# Altium schematic sheet Connect.SchDoc
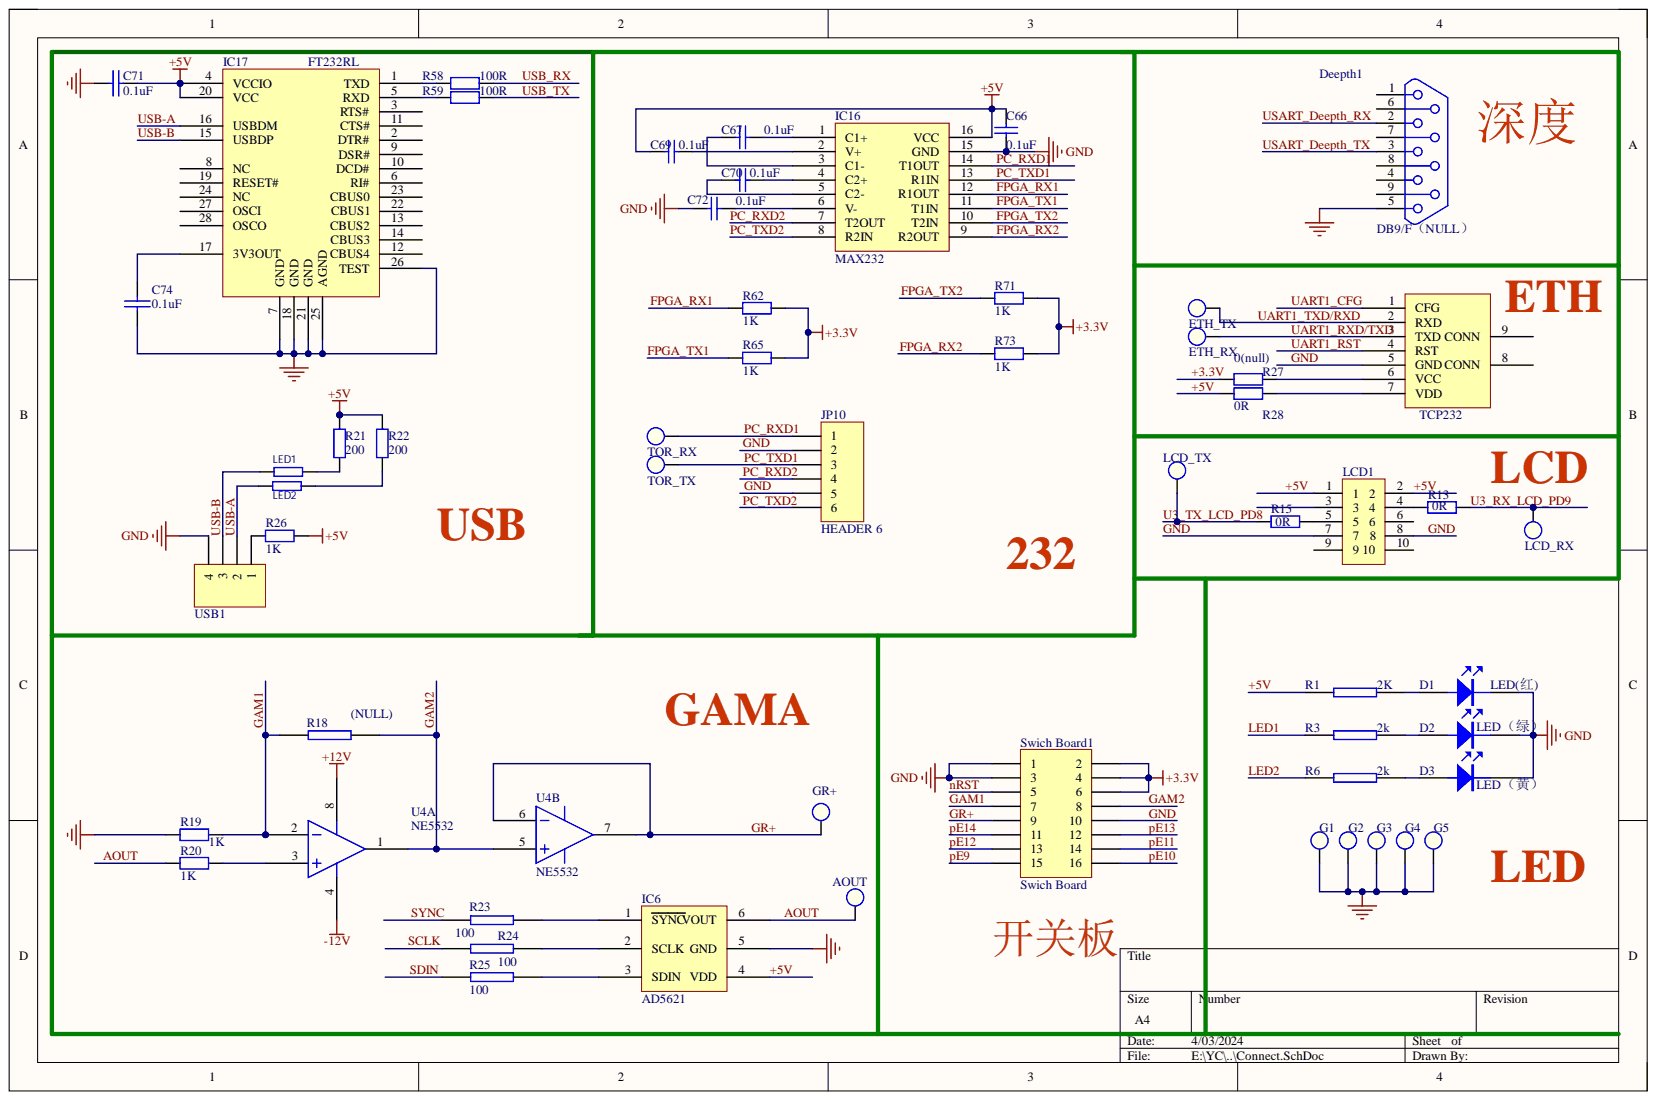
[diagram p0: Connect.SchDoc]

## Parts
AOUT  TERM  [TERM]  (value not drawn)
C66  0.1uF  [0805C]
C67  0.1uF  [0805C]
C69  0.1uF  [0805C]
C70  0.1uF  [0805C]
C71  0.1uF  [0805C]
C72  0.1uF  [0805C]
C74  0.1uF  [0805C]
D1  LED(红)  [1206D]
D2  LED（绿）  [1206D]
D3  LED（黄）  [1206D]
Deepth1  DB9/F（NULL）  [DB9/F]
ETH1(薄膜座)  Module_TCP232  [Module_TCP_232]  (value not drawn)
ETH_RX  TERM  [TERM]  (value not drawn)
ETH_TX  TERM  [TERM]  (value not drawn)
G1  TERM  [TERM]  (value not drawn)
G2  TERM  [TERM]  (value not drawn)
G3  TERM  [TERM]  (value not drawn)
G4  TERM  [TERM]  (value not drawn)
G5  TERM  [TERM]  (value not drawn)
GR+  TERM  [TERM]  (value not drawn)
IC6  AD5621  [SOP6]
IC16  MAX232  [SOP16]
IC17  FT232RL  [SSOP28]
JP10  HEADER 6  [J-DG128-6]
LCD1  HEADER 5X2  [IDC10_2.54]  (value not drawn)
LCD_RX  TERM  [TERM]  (value not drawn)
LCD_TX  TERM  [TERM]  (value not drawn)
LED1  (no value)  [0805D]
LED2  (no value)  [0805D]
R1  2K  [0805]
R3  2k  [0805]
R6  2k  [0805]
R13  0R  [0805]
R15  0R  [0805]
R18  (NULL)  [0805]
R19  1K  [0805]
R20  1K  [0805]
R21  200R  value 200  [0805]
R22  200R  value 200  [0805]
R23  100  [0805]
R24  100  [0805]
R25  100  [0805]
R26  1K  [0805]
R27  0(null)  [0805]
R28  0R  [0805]
R58  100R  [0805]
R59  100R  [0805]
R62  1K  [0805]
R65  1K  [0805]
R71  1K  [0805]
R73  1K  [0805]
Swich_Board1  Swich Board  [IDC16_2.54]
TOR_RX  TERM  [TERM]  (value not drawn)
TOR_TX  TERM  [TERM]  (value not drawn)
U4  NE5532  [SOIC8]  (parts A,B)
USB1  Header 4  [USB-A]  (value not drawn)

## Nets
+12V: U4.8
-12V: U4.4
+3.3V: R27.1 R62.1 R65.1 R71.1 R73.1 Swich_Board1.2 Swich_Board1.4 Swich_Board1.6
+5V: C66.2 C69.1 C71.1 IC6.4(VDD) IC16.16(VCC) IC17.4(VCCIO) IC17.20(VCC) LCD1.1 LCD1.2 R1.1 R21.2 R22.2 R26.2 R28.1
AOUT: AOUT.1 IC6.6(VOUT) R20.2
FPGA_RX1: IC16.12(R1OUT) R62.2
FPGA_RX2: IC16.9(R2OUT) R73.2
FPGA_TX1: IC16.11(T1IN) R65.2
FPGA_TX2: IC16.10(T2IN) R71.2
GAM1: R18.1 R19.1 Swich_Board1.7 U4.2
GAM2: R18.2 Swich_Board1.8 U4.1 U4.5
GND: C66.1 C71.2 C72.1 C74.2 D1.2 D2.2 D3.2 Deepth1.5 ETH1(薄膜座).5 G1.1 G2.1 G3.1 G4.1 G5.1 IC6.5(GND) IC16.15(GND) IC17.7(GND) IC17.18(GND) IC17.21(GND) IC17.25(AGND) IC17.26(TEST) JP10.2 JP10.5 LCD1.7 LCD1.8 R19.2 Swich_Board1.1 Swich_Board1.3 Swich_Board1.10 USB1.4
GR+: GR+.1 Swich_Board1.9 U4.6 U4.7
LED1: R3.1
LED2: R6.2
nRST: Swich_Board1.5
PC_RXD1: IC16.14(T1OUT) JP10.1 TOR_RX.1
PC_RXD2: IC16.7(T2OUT) JP10.4
PC_TXD1: IC16.13(R1IN) JP10.3 TOR_TX.1
PC_TXD2: IC16.8(R2IN) JP10.6
pE9: Swich_Board1.15
pE10: Swich_Board1.16
pE11: Swich_Board1.14
pE12: Swich_Board1.13
pE13: Swich_Board1.12
pE14: Swich_Board1.11
SCLK: R24.1
SDIN: R25.1
SYNC: R23.1
U3_RX_LCD_PD9: LCD_RX.1 R13.1
U3_TX_LCD_PD8: LCD_TX.1 R15.2
UART1_CFG: ETH1(薄膜座).1
UART1_RST: ETH1(薄膜座).4
UART1_RXD/TXD: ETH1(薄膜座).3 ETH_RX.1
UART1_TXD/RXD: ETH1(薄膜座).2 ETH_TX.1
USART_Deepth_RX: Deepth1.2
USART_Deepth_TX: Deepth1.3
USB-A: IC17.16(USBDM) LED2.1 USB1.2
USB-B: IC17.15(USBDP) LED1.1 USB1.3
USB_RX: R58.2
USB_TX: R59.2
NetC67_1: C67.1 IC16.3(C1-)
NetC67_2: C67.2 IC16.1(C1+)
NetC69_2: C69.2 IC16.2(V+)
NetC70_1: C70.1 IC16.5(C2-)
NetC70_2: C70.2 IC16.4(C2+)
NetC72_2: C72.2 IC16.6(V-)
NetC74_1: C74.1 IC17.17(3V3OUT)
NetD1_1: D1.1 R1.2
NetD2_1: D2.1 R3.2
NetD3_1: D3.1 R6.1
NetETH1(薄膜座)_6: ETH1(薄膜座).6 R27.2
NetETH1(薄膜座)_7: ETH1(薄膜座).7 R28.2
NetIC6_1: IC6.1(SYNC) R23.2
NetIC6_2: IC6.2(SCLK) R24.2
NetIC6_3: IC6.3(SDIN) R25.2
NetIC17_1: IC17.1(TXD) R58.1
NetIC17_5: IC17.5(RXD) R59.1
NetLCD1_4: LCD1.4 R13.2
NetLCD1_5: LCD1.5 R15.1
NetLED1_2: LED1.2 R21.1
NetLED2_2: LED2.2 R22.1
NetR20_1: R20.1 U4.3
NetR26_1: R26.1 USB1.1

Not connected: Deepth1 1 4 6 7 8 9; ETH1(薄膜座) 8 9; IC17 2(DTR#) 3(RTS#) 6(RI#) 8(NC) 9(DSR#) 10(DCD#) 11(CTS#) 12(CBUS4) 13(CBUS2) 14(CBUS3) 19(RESET#) 22(CBUS1) 23(CBUS0) 24(NC) 27(OSCI) 28(OSCO); LCD1 3 6 9 10

## Library data of the parts
C66 C67 C69 C70 C72 = Cap: Capacitor; Component Kind: Standard; LatestRevisionDate: 17-Jul-2002; LatestRevisionNote: Re-released for DXP Platform.; Library Name: Miscellaneous Devices.IntLib; PackageReference: RAD-0.3; Published: 8-Jun-2000; Signal Integrity: Cap; Simulation: CAP; Value: 0.1uF; Publisher: Altium Limited
C71 C74 = CAP: Capacitor; Component Kind: Standard; Value: 0.1uF
D1-D3 = LED: Component Kind: Standard; Library Name: 杂元件库.SCHLIB
Deepth1 = DB9: Component Kind: Standard; Library Name: 杂元件库.SCHLIB
JP10 = HEADER 6: 排针/排母; Component Kind: Standard; Library Name: TR_SCHLIB.SchLib
LCD1 = HEADER: Component Kind: Standard; Library Name: 杂元件库.SCHLIB
LED1 LED2 = RES: Component Kind: Standard; Library Name: 2.SCHLIB
R1 R3 R6 R18 R23-R25 = RES2: Component Kind: Standard
  R18: : (NULL); Library Name: Backup of 99SE.Lib
  R1 R3 R6: Library Name: downhole.SchLib
R13 R15 = Res2: Resistor; Value: 0; Component Kind: Standard; LatestRevisionDate: 17-Jul-2002; LatestRevisionNote: Re-released for DXP Platform.; Library Name: Miscellaneous Devices.IntLib; PackageDescription: Axial Device, Thru-Hole; 2 Leads; 0.4 in Pin Spacing; PackageReference: AXIAL-0.4; Published: 8-Jun-2000; Signal Integrity: Res; Simulation: RESISTOR; Publisher: Altium Limited
R19-R22 R26 R58 R59 R62 R65 R71 R73 = Res2: Resistor; Component Kind: Standard; LatestRevisionDate: 17-Jul-2002; LatestRevisionNote: Re-released for DXP Platform.; PackageDescription: Axial Device, Thru-Hole; 2 Leads; 0.4 in Pin Spacing; PackageReference: AXIAL-0.4; Published: 8-Jun-2000; Signal Integrity: Res; Simulation: RESISTOR; Publisher: Altium Limited
  R19 R20 R26 R62 R65 R71 R73: Library Name: CONN.SchLib; Value: 1K
  R21 R22: Library Name: CONN.SchLib
  R58 R59: Library Name: Miscellaneous Devices.IntLib; Value: 100R
R27 R28 = RES: 通用; Component Kind: Standard; Library Name: TR_SCHLIB.SchLib
Swich_Board1 = HEADER 8X2: 排针/排母; Component Kind: Standard; Library Name: TR_SCHLIB.SchLib
USB1 = Header 4: Header, 4-Pin; Component Kind: Standard; LatestRevisionDate: 17-Jul-2002; LatestRevisionNote: Re-released for DXP Platform.; Library Name: 5.SCHLIB; Signal Integrity: Connector; Publisher: Altium Limited
AOUT ETH_RX ETH_TX G1-G5 GR+ LCD_RX LCD_TX TOR_RX TOR_TX = TERM: Component Kind: Standard
  ETH_RX ETH_TX GR+ LCD_RX LCD_TX TOR_RX TOR_TX: Library Name: TR_SCHLIB.SchLib
ETH1(薄膜座) = Module_TCP232: Component Kind: Standard; Library Name: TR_SCHLIB.SchLib
IC6 = AD5621: Component Kind: Standard
IC16 = MAX232: Component Kind: Standard; Library Name: Schlib.SchLib
IC17 = FT232RL: Component Kind: Standard
U4 = NE5532: Component Kind: Standard; Library Name: Previous Backup of Schlib1.Lib
